# Altium schematic sheet 7.LED.SchDoc
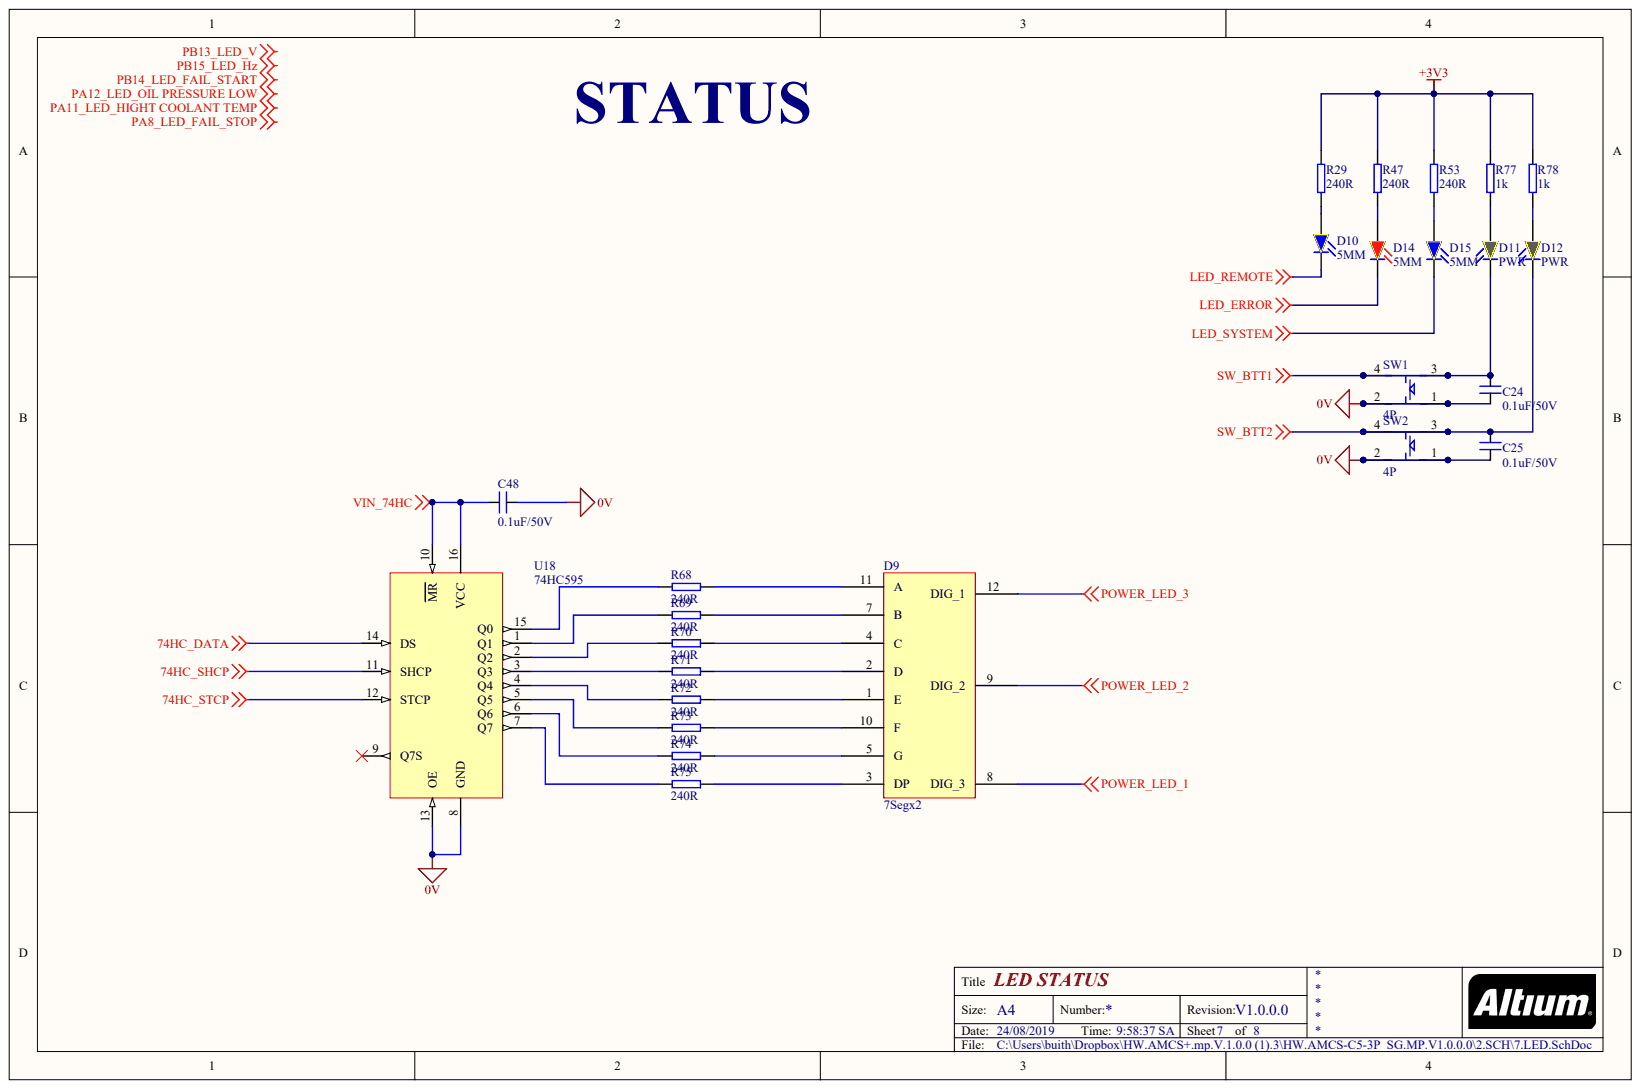
[diagram p0: 7.LED.SchDoc]

## Parts
C24  0.1uF/50V  [0805]
C25  0.1uF/50V  [0805]
C48  0.1uF/50V  [0805]
D9  7Segx2  [LED7x3SEG_0.28]
D10  5MM  [LED_BLUE_5mm]
D11  PWR  [0805_Pol]
D12  PWR  [0805_Pol]
D14  5MM  [LED_RED_5mm]
D15  5MM  [LED_BLUE_5mm]
R29  240R  [0805]
R47  240R  [0805]
R53  240R  [0805]
R68  240R  [0805]
R69  240R  [0805]
R70  240R  [0805]
R71  240R  [0805]
R72  240R  [0805]
R73  240R  [0805]
R74  240R  [0805]
R75  240R  [0805]
R77  1k  [0805]
R78  1k  [0805]
SW1  4P  [SW_4P_6x6]
SW2  4P  [SW_4P_6x6]
U18  74HC595  [SOIC-16]

## Nets
0V: C24.2 C25.2 C48.2 SW1.1 SW1.2 SW2.1 SW2.2 U18.8(GND) U18.13(OE)
74HC_DATA: U18.14(DS)
74HC_SHCP: U18.11(SHCP)
74HC_STCP: U18.12(STCP)
+3V3: R29.2 R47.2 R53.2 R77.1 R78.1
LED_ERROR: D14.2
LED_REMOTE: D10.2
LED_SYSTEM: D15.2
POWER_LED_1: D9.8(DIG_3)
POWER_LED_2: D9.9(DIG_2)
POWER_LED_3: D9.12(DIG_1)
SW_BTT1: C24.1 D11.2 SW1.3 SW1.4
SW_BTT2: C25.1 D12.2 SW2.3 SW2.4
VIN_74HC: C48.1 U18.10(MR) U18.16(VCC)
NetD9_1: D9.1(E) R72.1
NetD9_2: D9.2(D) R71.1
NetD9_3: D9.3(DP) R75.1
NetD9_4: D9.4(C) R70.1
NetD9_5: D9.5(G) R74.1
NetD9_7: D9.7(B) R69.1
NetD9_10: D9.10(F) R73.1
NetD9_11: D9.11(A) R68.1
NetD10_1: D10.1 R29.1
NetD11_1: D11.1 R77.2
NetD12_1: D12.1 R78.2
NetD14_1: D14.1 R47.1
NetD15_1: D15.1 R53.1
NetR68_2: R68.2 U18.15(Q0)
NetR69_2: R69.2 U18.1(Q1)
NetR70_2: R70.2 U18.2(Q2)
NetR71_2: R71.2 U18.3(Q3)
NetR72_2: R72.2 U18.4(Q4)
NetR73_2: R73.2 U18.5(Q5)
NetR74_2: R74.2 U18.6(Q6)
NetR75_2: R75.2 U18.7(Q7)
PA8_LED_FAIL_STOP:
PA11_LED_HIGHT COOLANT TEMP:
PA12_LED_OIL PRESSURE LOW:
PB13_LED_V:
PB14_LED_FAIL_START:
PB15_LED_HZ:

Not connected: U18 9(Q7S)

## Library data of the parts
C24 C25 C48 = Cap_0.1uF_0805: CAP CER 0.1UF 50V Y5V 0805; MFR: Yageo; Part Number: CC0805ZRY5V9BB104; AMCS CODE: VT-CAP-0004; ASS: SMT; Component Kind: Standard; Package: 0805; TYPE: CAP; Library Name: Schlib_Diepvn_V01.SchLib
D9 = LED-7segx3-0.28'': LED 7 seg 0.28' Anot Red; Part Number: LDS-2381BX; Component Kind: Standard; NOTE 1: https://banlinhkien.vn/goods-3647-led-7-0-28-3-so-anot-mau-do-.html; Package: 0.28'; Library Name: Schlib_Diepvn_V01.SchLib
D10 D15 = LED_BLUE_5MM: LED 5MM HI EFF BLUE DIFF; MFR: Vishay Semiconductor Opto Division; ASS: TH; TYPE: DIO; Component Kind: Standard; LINK: http://linhkienchatluong.vn/led-don-f5-5mm/led-5mm-xanh-la-10c_sp697_ct1893.aspx; Package: Pitch:5mm; Library Name: Schlib_Diepvn_V01.SchLib
D11 D12 = LED_Gr_1: LED RED CLEAR 0805 SMD; MFR: Lite-On; Part Number: LTST-C171KRKT; AMCS CODE: VT-DIO-0006; ASS: SMT; TYPE: DIO; Component Kind: Standard; Package: 0805; Library Name: Schlib_Diepvn_V01.SchLib
D14 = LED_RED_5MM: LED 5MM HI EFF BLUE DIFF; MFR: Vishay Semiconductor Opto Division; ASS: TH; TYPE: DIO; Component Kind: Standard; LINK: http://linhkienchatluong.vn/led-don-f5-5mm/led-5mm-do-10c_sp697_ct4249.aspx; Package: Pitch:5mm; Library Name: Schlib_Diepvn_V01.SchLib
R29 R47 R53 R68-R75 = Res_240R_0805: RES SMD 240 OHM 1% 1/8W 0805; MFR: Yageo; Part Number: RC0805FR-07240RL; AMCS CODE: VT-RES-0015; ASS: SMT; Vender: Digikey; Component Kind: Standard; Package: 0805; TYPE: RES; Library Name: Schlib_Diepvn_V01.SchLib
R77 R78 = Res_1k_0805: RES 1K OHM 1/10W 1% 0603 SMD; MFR: Yageo; Part Number: RC0805FR-071KL; AMCS CODE: VT-RES-0003; ASS: SMT; Component Kind: Standard; Package: 0805; TYPE: RES; Library Name: Schlib_Diepvn_V01.SchLib
SW1 SW2 = SW_4Pin_6x6x5: 6x6x5mm; ASS: TH; Component Kind: Standard; LINK: http://linhkienchatluong.vn/nut-nhan-6x6-4p-dip/nut-nhan-4-chan-6x6x5mm-dip-tui-1000c_sp851_ct1807.aspx; Package: DIP4; Library Name: Schlib_Diepvn_V01.SchLib
U18 = 74HC595: IC SHIFT REGISTER 8BIT 16SOIC; MFR: Nexperia USA Inc.; Part Number: 74HC595D,118; Part Number 1: MC74HC595ADR2G; ASS: SMT; Component Kind: Standard; Package: 16-SOIC (0.154", 3.90mm Width); Part Number 2: SN74HC595DR; Library Name: Schlib_Diepvn_V01.SchLib
